# Altium schematic sheet Sensors_RECU.SchDoc(Sensors_RECU)
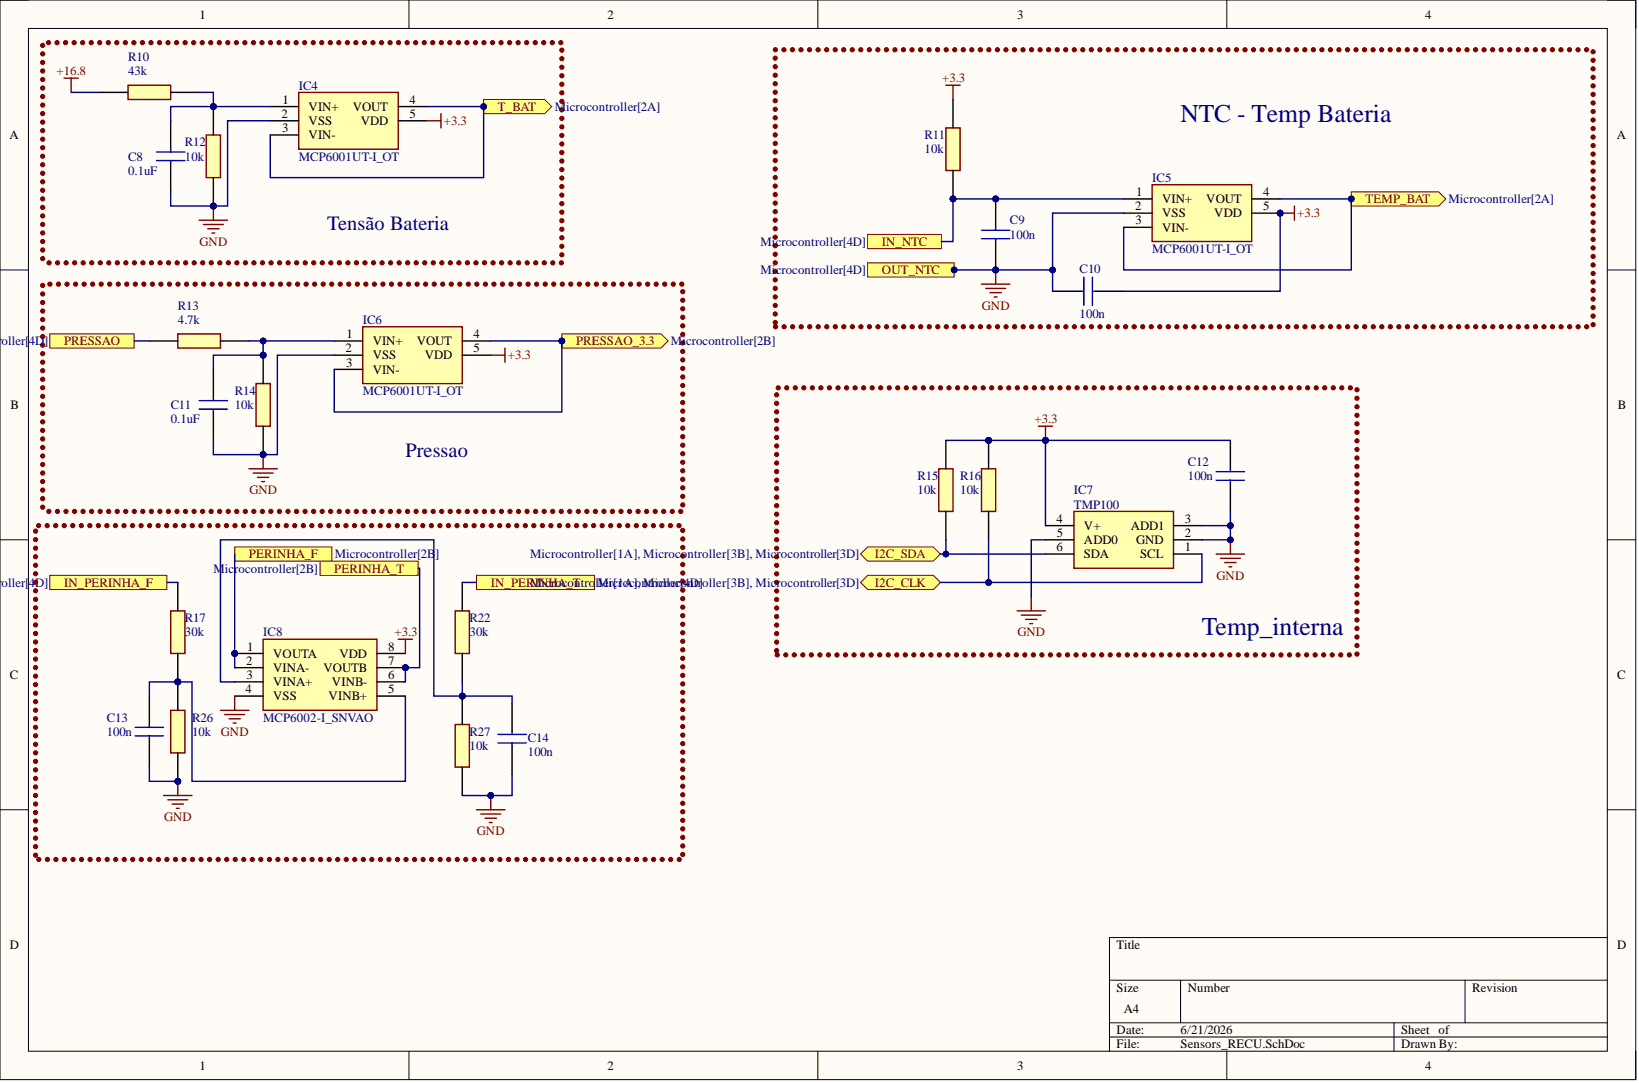
[diagram p0: Sensors_RECU.SchDoc]

## Parts
C8  0.1uF
C9  100n
C10  100n
C11  0.1uF
C12  100n
C13  100n
C14  100n
IC4  MCP6001UT-I_OT
IC5  MCP6001UT-I_OT
IC6  MCP6001UT-I_OT
IC7  TMP100
IC8  MCP6002-I_SNVAO
R10  43k
R11  10k
R12  10k
R13  4.7k
R14  10k
R15  10k
R16  10k
R17  30k
R22  30k
R26  10k
R27  10k

## Ports
I2C_CLK, I2C_SDA, IN_NTC, IN_PERINHA_F, IN_PERINHA_T, OUT_NTC, PERINHA_F, PERINHA_T, PRESSAO, PRESSAO_3.3, T_BAT, TEMP_BAT

## Nets
+3.3: C10.1 C12.2 IC4.5(VDD) IC5.5(VDD) IC6.5(VDD) IC7.4(V+) IC8.8(VDD) R11.2 R15.1 R16.1
+16.8: R10.1
GND: C8.1 C9.1 C10.2 C11.1 C12.1 C13.1 C14.1 IC4.2(VSS) IC5.2(VSS) IC6.2(VSS) IC7.2(GND) IC7.3(ADD1) IC7.5(ADD0) IC8.4(VSS) R12.1 R14.1 R26.1 R27.1 ; port OUT_NTC
I2C_CLK: IC7.1(SCL) R16.2 ; port I2C_CLK
I2C_SDA: IC7.6(SDA) R15.2 ; port I2C_SDA
IN_NTC: C9.2 IC5.1(VIN+) R11.1 ; port IN_NTC
IN_PERINHA_F: R17.2 ; port IN_PERINHA_F
IN_PERINHA_T: R22.2 ; port IN_PERINHA_T
PERINHA_F: IC8.1(VOUTA) IC8.2(VINA-) ; port PERINHA_F
PERINHA_T: IC8.6(VINB-) IC8.7(VOUTB) ; port PERINHA_T
PRESSAO: R13.1 ; port PRESSAO
PRESSAO_3.3: IC6.3(VIN-) IC6.4(VOUT) ; port PRESSAO_3.3
T_BAT: IC4.3(VIN-) IC4.4(VOUT) ; port T_BAT
TEMP_BAT: IC5.3(VIN-) IC5.4(VOUT) ; port TEMP_BAT
NetC8_2: C8.2 IC4.1(VIN+) R10.2 R12.2
NetC11_2: C11.2 IC6.1(VIN+) R13.2 R14.2
NetC13_2: C13.2 IC8.5(VINB+) R17.1 R26.2
NetC14_2: C14.2 IC8.3(VINA+) R22.1 R27.2

## Library data of the parts
C8 C11 = KAF21BR71H104JM: Capacitor; Manufacturer_Name: Kyocera AVX; Component Kind: Standard; Datasheet Link: https://www.mouser.de/datasheet/2/40/AutoMLCCKAF-3218225.pdf; Height: 0.94mm; Manufacturer_Part_Number: KAF21BR71H104JM; Mouser Part Number: 581-KAF21BR71H104JM; Mouser Price/Stock: https://www.mouser.co.uk/ProductDetail/KYOCERA-AVX/KAF21BR71H104JM?qs=Jm2GQyTW%2FbjLJnSOuM%2FLDw%3D%3D
C9 C10 C12-C14 = CL21B104KBCNNNC: Capacitor; Manufacturer_Name: SAMSUNG; Arrow Part Number: CL21B104KBCNNNC; Arrow Price/Stock: https://www.arrow.com/en/products/cl21b104kbcnnnc/samsung-electro-mechanics?region=europe; Component Kind: Standard; Datasheet Link: https://datasheet.datasheetarchive.com/originals/distributors/Datasheets_SAMA/86a3eaf0882763ef32124d5901f9b833.pdf; Height: 0.95mm; Manufacturer_Part_Number: CL21B104KBCNNNC; Mouser Part Number: 187-CL21B104KBCNNNC; Mouser Price/Stock: https://www.mouser.co.uk/ProductDetail/Samsung-Electro-Mechanics/CL21B104KBCNNNC?qs=349EhDEZ59pEfpnm5yBEmQ%3D%3D
IC4-IC6 = MCP6001UT-I_OT: Integrated Circuit; Manufacturer_Name: Microchip; Manufacturer_Part_Number: MCP6001UT-I/OT; Arrow Part Number: MCP6001UT-I/OT; Arrow Price/Stock: https://www.arrow.com/en/products/mcp6001ut-iot/microchip-technology?utm_currency=USD&region=nac; Component Kind: Standard; Datasheet Link: http://componentsearchengine.com/Datasheets/3/MCP6001UT-I_OT.pdf; Height: 1.45mm; Mouser Part Number: 579-MCP6001UT-I/OT; Mouser Price/Stock: https://www.mouser.co.uk/ProductDetail/Microchip-Technology/MCP6001UT-I-OT?qs=Sez7gRs8XSU2iw24kVuIOw%3D%3D
IC7 = TMP100NA_3K: Integrated Circuit; Manufacturer_Name: Texas Instruments; Manufacturer_Part_Number: TMP100NA/3K; Arrow Part Number: TMP100NA/3K; Arrow Price/Stock: https://www.arrow.com/en/products/tmp100na3k/texas-instruments?utm_currency=USD&region=europe; Component Kind: Standard; Datasheet Link: https://www.ti.com/lit/gpn/TMP100; Height: 1.45mm; Mouser Part Number: 595-TMP100NA/3K; Mouser Price/Stock: https://www.mouser.co.uk/ProductDetail/Texas-Instruments/TMP100NA-3K?qs=RnzODY3cU8ttwUxnXA%252BgRQ%3D%3D
IC8 = MCP6002-I_SNVAO: Integrated Circuit; Manufacturer_Name: Microchip; Manufacturer_Part_Number: MCP6002-I/SNVAO; Arrow Part Number: MCP6002-I/SNVAO; Arrow Price/Stock: https://www.arrow.com/en/products/mcp6002-isnvao/microchip-technology; Component Kind: Standard; Datasheet Link: http://ww1.microchip.com/downloads/en/DeviceDoc/MCP6001-1R-1U-2-4-1-MHz-Low-Power-Op-Amp-DS20001733L.pdf; Height: 1.75mm; Mouser Part Number: 579-MCP6002-I/SNVAO; Mouser Price/Stock: https://www.mouser.co.uk/ProductDetail/Microchip-Technology/MCP6002-I-SNVAO?qs=5aG0NVq1C4y7OR6tqTOKSA%3D%3D
R10 R17 R22 = CR0805-FX-4302ELF: Resistor; Manufacturer_Name: Bourns; Arrow Part Number: CR0805-FX-4302ELF; Arrow Price/Stock: https://www.arrow.com/en/products/cr0805-fx-4302elf/bourns; Component Kind: Standard; Datasheet Link: https://www.bourns.com/pdfs/chpreztr.pdf; Height: 0.6mm; Manufacturer_Part_Number: CR0805-FX-4302ELF; Mouser Part Number: 652-CR0805FX-4302ELF; Mouser Price/Stock: https://www.mouser.co.uk/ProductDetail/Bourns/CR0805-FX-4302ELF?qs=TYKCbaKwe1ApIWYNO0ZfXw%3D%3D
R11 R12 R14 R26 R27 = 4-2176238-9: Resistor; Manufacturer_Name: TE Connectivity; Component Kind: Standard; Datasheet Link: https://www.te.com/commerce/DocumentDelivery/DDEController?Action=srchrtrv&DocNm=1773200-1&DocType=Data%20Sheet&DocLang=English&DocFormat=pdf&PartCntxt=4-2176238-9; Height: 0.65mm; Manufacturer_Part_Number: 4-2176238-9
R13 = ERA-6AED472V: Resistor; Manufacturer_Name: Panasonic; Component Kind: Standard; Datasheet Link: https://industrial.panasonic.com/cdbs/www-data/pdf/RDM0000/AOA0000C307.pdf; Height: 0.6mm; Manufacturer_Part_Number: ERA-6AED472V; Mouser Part Number: 667-ERA-6AED472V; Mouser Price/Stock: https://www.mouser.co.uk/ProductDetail/Panasonic/ERA-6AED472V?qs=MNPzkKEzRtSzMasSI0sk9w%3D%3D
R15 R16 = RC0805FR-0710KL: Resistor; Manufacturer_Name: YAGEO; Arrow Part Number: RC0805FR-0710KL; Arrow Price/Stock: https://www.arrow.com/en/products/rc0805fr-0710kl/yageo?utm_currency=USD&region=europe; Component Kind: Standard; Datasheet Link: https://www.yageo.com/upload/media/product/productsearch/datasheet/rchip/PYu-RC_Group_51_RoHS_L_11.pdf; Height: 0.6mm; Manufacturer_Part_Number: RC0805FR-0710KL; Mouser Part Number: 603-RC0805FR-0710KL; Mouser Price/Stock: https://www.mouser.co.uk/ProductDetail/YAGEO/RC0805FR-0710KL?qs=7bY8808IzHq6vrU3BeiiYw%3D%3D
